# Altium schematic sheet CVD01(Q2)-GS(DC)260806CN-V2.0B2.0.SchDoc(CVD01(Q2)-GS(DC)260806CN-V2.0B2.0)
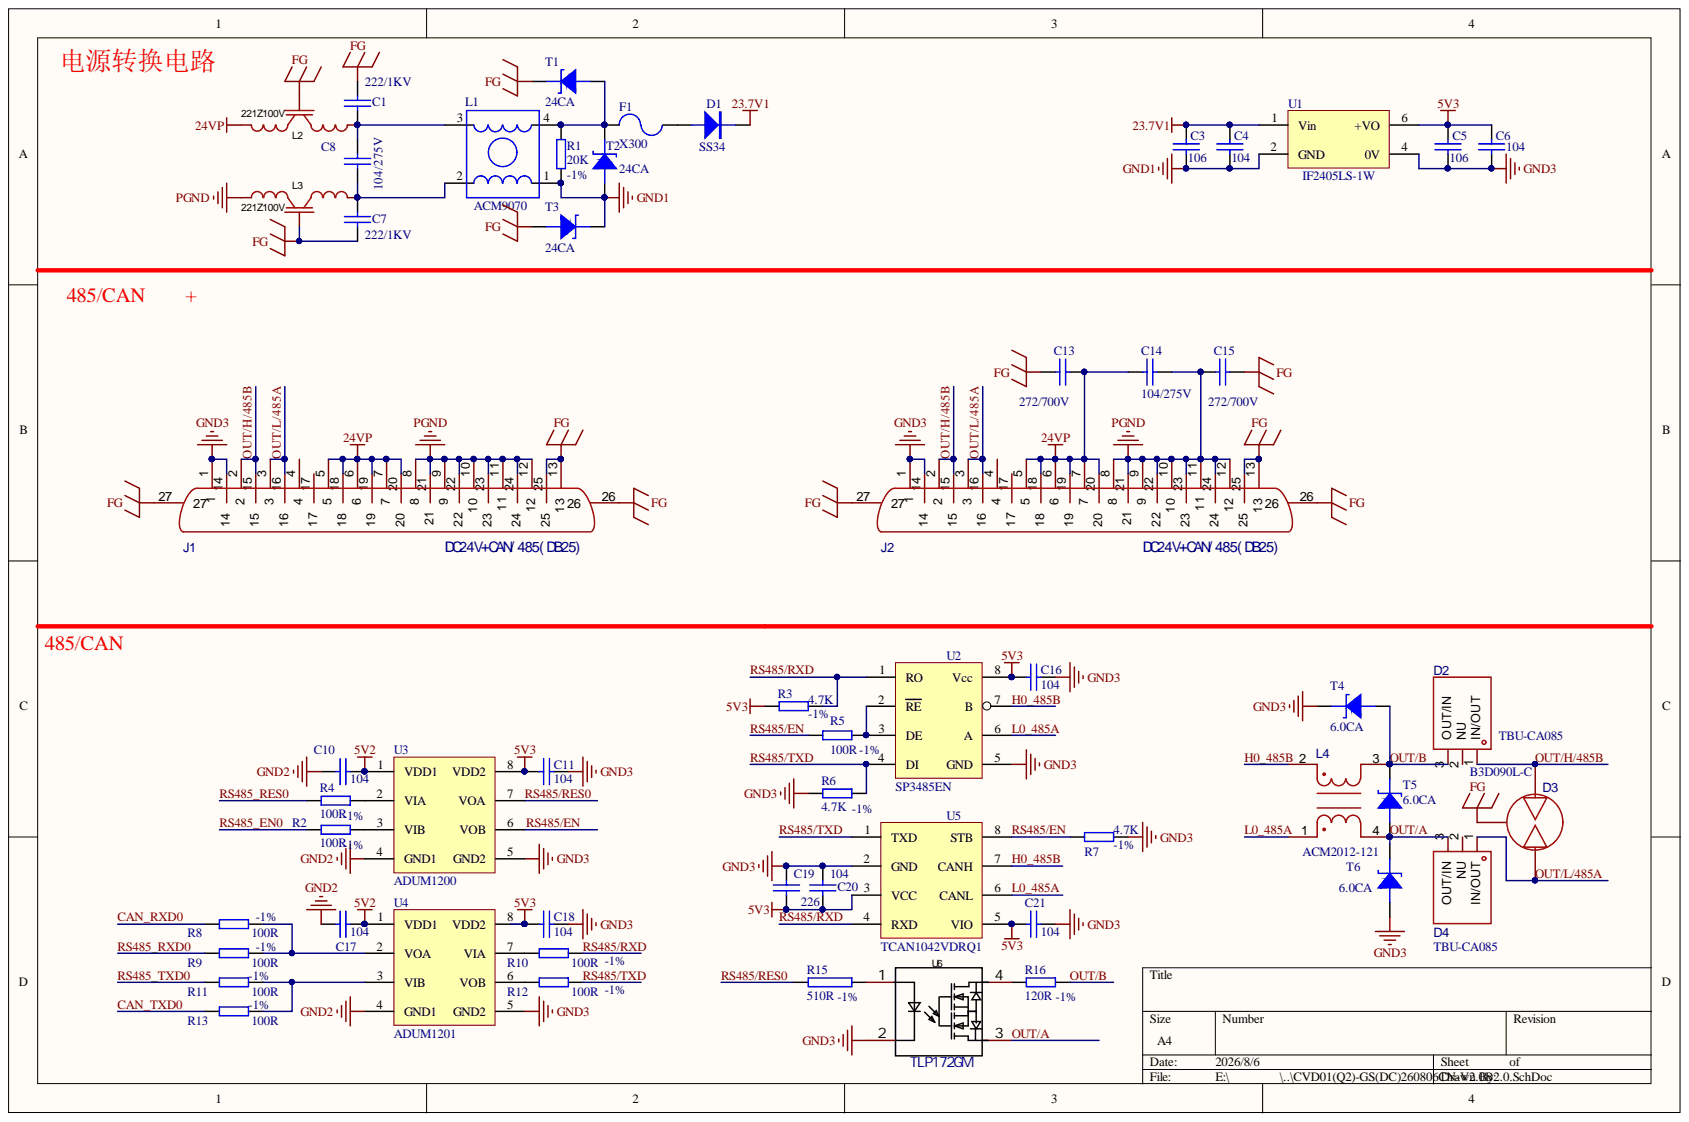
[diagram p0: CVD01]

## Parts
C1  222/1KV  [RAD0.2]
C3  106  [1206]
C4  104  [0603]
C5  106  [1206]
C6  104  [0603]
C7  222/1KV  [RAD0.2]
C8  104/275V  [RAD0.4]
C10  104  [0603]
C11  104  [0603]
C13  272/700V  [RAD0.4]
C14  104/275V  [RAD0.4]
C15  272/700V  [RAD0.4]
C16  104  [0603]
C17  104  [0603]
C18  104  [0603]
C19  226  [0603]
C20  104  [0603]
C21  104  [0603]
D1  SS34  [DO-214AC]
D2  TBU-CA085  [DIO-SMD_3P-L6.5-W4.0-BI]
D3  B3D090L-C  [GDT-SMD_3P-L7.3-W5.0-L]
D4  TBU-CA085  [DIO-SMD_3P-L6.5-W4.0-BI]
F1  X300  [1812]
J1  DC24V+CAN/485(DB25)  [D-SUB-DR25母头]
J2  DC24V+CAN/485(DB25)  [D-SUB-DR25母头]
L1  ACM9070  [ACM9070-701-2P]
L2  221Z100V  [FILTER-TH_3P-L8.0-W3.5-P2.54-R]
L3  221Z100V  [FILTER-TH_3P-L8.0-W3.5-P2.54-R]
L4  ACM2012-121  [FILTER-SMD_0805-4P-L2.0-W1.2-BL]
R1  20K  ERROR: -1%  [0805]
R2  100R  ERROR: -1%  [0603]
R3  4.7K  ERROR: -1%  [0603]
R4  100R  [0603]
R5  100R  ERROR: -1%  [0603]
R6  4.7K  ERROR: -1%  [0603]
R7  4.7K  ERROR: -1%  [0603]
R8  100R  ERROR: -1%  [0603]
R9  100R  ERROR: -1%  [0603]
R10  100R  ERROR: -1%  [0603]
R11  100R  ERROR: -1%  [0603]
R12  100R  ERROR: -1%  [0603]
R13  100R  ERROR: -1%  [0603]
R15  510R  ERROR: -1%  [0603]
R16  120R  ERROR: -1%  [1206]
T1  24CA  [1812(T)]
T2  24CA  [1812(T)]
T3  24CA  [1812(T)]
T4  6.0CA  [SOD-123]
T5  6.0CA  [SOD-123]
T6  6.0CA  [SOD-123]
U1  IF2405LS-1W  [IB_LS-1W]
U2  SP3485EN  [SO-8]
U3  ADUM1200  [SO-8]
U4  ADUM1201  [SO-8]
U5  TCAN1042VDRQ1  [SO-8]
U6  TLP172GM  [SMD-4]

## Nets
5V2: C10.2 C17.2 U3.1(VDD1) U4.1(VDD1)
5V3: C5.1 C6.1 C11.1 C16.2 C18.1 C19.2 C20.2 C21.1 R3.2 U1.6(+VO) U2.8(Vcc) U3.8(VDD2) U4.8(VDD2) U5.3(VCC) U5.5(VIO)
23.7V1: C3.1 C4.1 D1.2 U1.1(Vin)
24VP: C13.1 C14.2 J1.5 J1.6 J1.7 J1.18 J1.19 J1.20 J2.5 J2.6 J2.7 J2.18 J2.19 J2.20 L2.1
FG: C1.2 C7.1 C13.2 C15.2 D3.2 J1.13 J1.25 J1.26 J1.27 J2.13 J2.25 J2.26 J2.27 L2.2 L3.2 T1.2 T3.1
GND1: C3.2 C4.2 L1.1 R1.2 T2.1 T3.2 U1.2(GND)
GND2: C10.1 C17.1 U3.4(GND1) U4.4(GND1)
GND3: C5.2 C6.2 C11.2 C16.1 C18.2 C19.1 C20.1 C21.2 J1.1 J1.14 J2.1 J2.14 R6.2 R7.2 T4.2 T6.1 U1.4(0V) U2.5(GND) U3.5(GND2) U4.5(GND2) U5.2(GND) U6.2
PGND: C14.1 C15.1 J1.8 J1.9 J1.10 J1.11 J1.12 J1.21 J1.22 J1.23 J1.24 J2.8 J2.9 J2.10 J2.11 J2.12 J2.21 J2.22 J2.23 J2.24 L3.1
CAN_RXD0: R8.1
CAN_TXD0: R13.1
H0_485B: L4.2 U2.7(B) U5.7(CANH)
L0_485A: L4.1 U2.6(A) U5.6(CANL)
OUT/A: D4.3 L4.4 T5.1 T6.2 U6.3
OUT/B: D2.3 L4.3 R16.2 T4.1 T5.2
OUT/H/485B: D2.1 D3.1 J1.2 J1.15 J2.2 J2.15
OUT/L/485A: D3.3 D4.1 J1.3 J1.16 J2.3 J2.16
RS485/EN: R5.1 R7.1 U3.6(VOB) U5.8(STB)
RS485/RES0: R15.1 U3.7(VOA)
RS485/RXD: R3.1 R10.2 U2.1(RO) U5.4(RXD)
RS485/TXD: R6.1 R12.2 U2.4(DI) U5.1(TXD)
RS485_EN0: R2.1
RS485_RES0: R4.1
RS485_RXD0: R9.1
RS485_TXD0: R11.1
NetC1_1: C1.1 C8.1 L1.3 L2.3
NetC7_2: C7.2 C8.2 L1.2 L3.3
NetD1_1: D1.1 F1.1
NetF1_2: F1.2 L1.4 R1.1 T1.1 T2.2
NetR2_2: R2.2 U3.3(VIB)
NetR4_2: R4.2 U3.2(VIA)
NetR5_2: R5.2 U2.2(RE) U2.3(DE)
NetR8_2: R8.2 R9.2 U4.2(VOA)
NetR10_1: R10.1 U4.7(VIA)
NetR11_2: R11.2 R13.2 U4.3(VIB)
NetR12_1: R12.1 U4.6(VOB)
NetR15_2: R15.2 U6.1
NetR16_1: R16.1 U6.4

Not connected: D2 2; D4 2; J1 4 17; J2 4 17

## Library data of the parts
C1 C7 = CAP: 无极性电容; NAME: 安规电容; MODEL: 222/1KV; Component Kind: Standard; Library Name: ChangAi-Library-3.00-191203-ZC.SchLib
C3 C5 = CAP: 无极性电容; NAME: 贴片电容; MODEL: 1206-106; Component Kind: Standard; Library Name: ChangAi-Library-3.00-191203-ZC.SchLib
C4 C6 C10 C11 C16-C18 C20 C21 = CAP: 无极性电容; NAME: 贴片电容; MODEL: 0603-104; Component Kind: Standard
  C4 C6: Library Name: ChangAi-Library-3.00-191203-ZC.SchLib
  C10 C11 C16-C18 C20 C21: Library Name: ChangAi-Library-4.00-200110-ZC.IntLib
C8 C14 = CAP: 无极性电容; NAME: 安规电容; MODEL: 0.1uF/275V; Component Kind: Standard; Library Name: ChangAi-Library-3.00-191203-ZC.SchLib
C13 C15 = CAP: 无极性电容; NAME: 聚丙烯膜电容(CBB); MODEL: 2.7nF ±5% 700V; Component Kind: Standard; Library Name: ChangAi-Library-3.00-191203-ZC.SchLib
C19 = CAP: 无极性电容; NAME: 贴片电容; MODEL: 0603-226; Component Kind: Standard; Library Name: ChangAi-Library-4.00-200110-ZC.IntLib
D1 = DIODE: 贴片二极管; MODEL: 1N5822(SS34); PRICE: 0.18; NAME: 贴片二极管; Component Kind: Standard; Library Name: ChangAi-Library-3.00-191203-ZC.SchLib
D2 D4 = TBU-CA085-100-WH: NAME: 过流保护器; PRICE: 4.9; MODEL: TBU-CA085-100-WH; Component Kind: Standard
D3 = B3D090L-C: NAME: 气体放电管; PRICE: 2; MODEL: B3D090L-C; Component Kind: Standard
F1 = FUSE: 自恢复保险管; MODEL: 1812X300 (SMD1812P300SLR/24); PRICE: 0.45; NAME: 自恢复保险管; Component Kind: Standard; Library Name: ChangAi-Library-2.00-181023-ZZM.IntLib
J1 J2 = D-SUB-DR-25PCM-BB: NAME: DB插座; MODEL: D-SUB-DR-25PCM-BB; Component Kind: Standard
L1 = L_UU10.5-10MH: 1A共模电感; NAME: 共模电感; MODEL: ACM9070-701-2PL-TL01; PRICE: 3.8; Component Kind: Standard; Library Name: ChangAi-Library-3.00-191203-ZC.SchLib
L2 L3 = DSS1NB32A222Q55B: Manufacturer: muRata(村田); NAME: 三端滤波电容; Convert to PCB: yes; Supplier Part: C723882; Supplier Footprint: 插件; Supplier: LCSC; MODEL: DSS1NB32A222Q55B; Manufacturer Part: DSS1NB32A222Q55B; JLCPCB Part Class: Extended Part; Device: DSS1NB32A222Q55B; Datasheet: https://atta.szlcsc.com/upload/public/pdf/source/20200807/C710600_33E7A4F753A0E668311DD556311E590D.pdf; Component Kind: Standard; Comment_1: DSS1NB32A222Q55B; Add into BOM: yes
L4 = ACM2012-121-2P-T002: NAME: 贴片共模电感; PRICE: 0.7; MODEL: ACM2012-121-2P-T002; Component Kind: Standard
R1 = RES: 1%精度; NAME: 贴片电阻; MODEL: 0805-20K; Component Kind: Standard; Library Name: ChangAi-Library-3.00-191203-ZC.SchLib
R2 R5 R8-R13 = RES: 1%精度; NAME: 贴片电阻; MODEL: 0603-100R; Component Kind: Standard; Library Name: ChangAi-Library-4.00-200110-ZC.IntLib
R3 R6 R7 = RES: 1%精度; NAME: 贴片电阻; MODEL: 0603-4.7K; Component Kind: Standard; Library Name: ChangAi-Library-4.00-200110-ZC.IntLib
R4 = RES: 1%精度; NAME: 贴片电阻; MODEL: 0603-100R; ERROR: -1%; Component Kind: Standard; Library Name: ChangAi-Library-4.00-200110-ZC.IntLib
R15 = RES1: 5%精度; NAME: 贴片电阻; MODEL: 0603-510R; Component Kind: Standard
R16 = RES: 1%精度; NAME: 贴片电阻; MODEL: 1206-120R; Component Kind: Standard; Library Name: ChangAi-Library-3.00-191203-ZC.SchLib
T1-T3 = ZENER: TVS管; MODEL: LM1K24CA; NAME: TVS管; Component Kind: Standard; Library Name: ChangAi-Library-4.00-200110-ZC.SchLib
T4-T6 = ZENER: TVS管; MODEL: PMF6.0CA; PRICE: 0.15; NAME: TVS管; Component Kind: Standard; Library Name: ChangAi-Library-4.00-200110-ZC.IntLib
U1 = IBXXXXLS-1W: DC模块; PRICE: 10; NAME: DC模块; MODEL: IF2405LS-1W; Component Kind: Standard; Library Name: ChangAi-Library-3.00-191203-ZC.SchLib
U2 = SP3485EN: 串口转485; NAME: 贴片集成块; PRICE: 1.45; MODEL: SP3485EN; Component Kind: Standard; Library Name: ChangAi-Library-4.00-200110-ZC.IntLib
U3 = ADUM1200ARZ: 磁耦; NAME: 贴片集成块; MODEL: ADUM1200ARZ; Component Kind: Standard; Library Name: ChangAi-Library-3.00-191203-ZC.SchLib
U4 = ADUM1201ARZ: 磁耦; NAME: 贴片集成块; PRICE: 2.5; MODEL: ADUM1201ARZ; Component Kind: Standard; Library Name: ChangAi-Library-4.00-200110-ZC.IntLib
U5 = TCAN1042VDRQ1: 串口转CAN; NAME: 贴片集成块; PRICE: 11.5; MODEL: TCAN1042VDRQ1; Component Kind: Standard; Library Name: ChangAi-Library-3.00-191203-ZC.SchLib
U6 = TLP172GM(TPL,E(O: NAME: 贴片集成块; PRICE: 2; MODEL: TLP172GM; Component Kind: Standard
